# Altium schematic sheet RFID_CRD.SchDoc
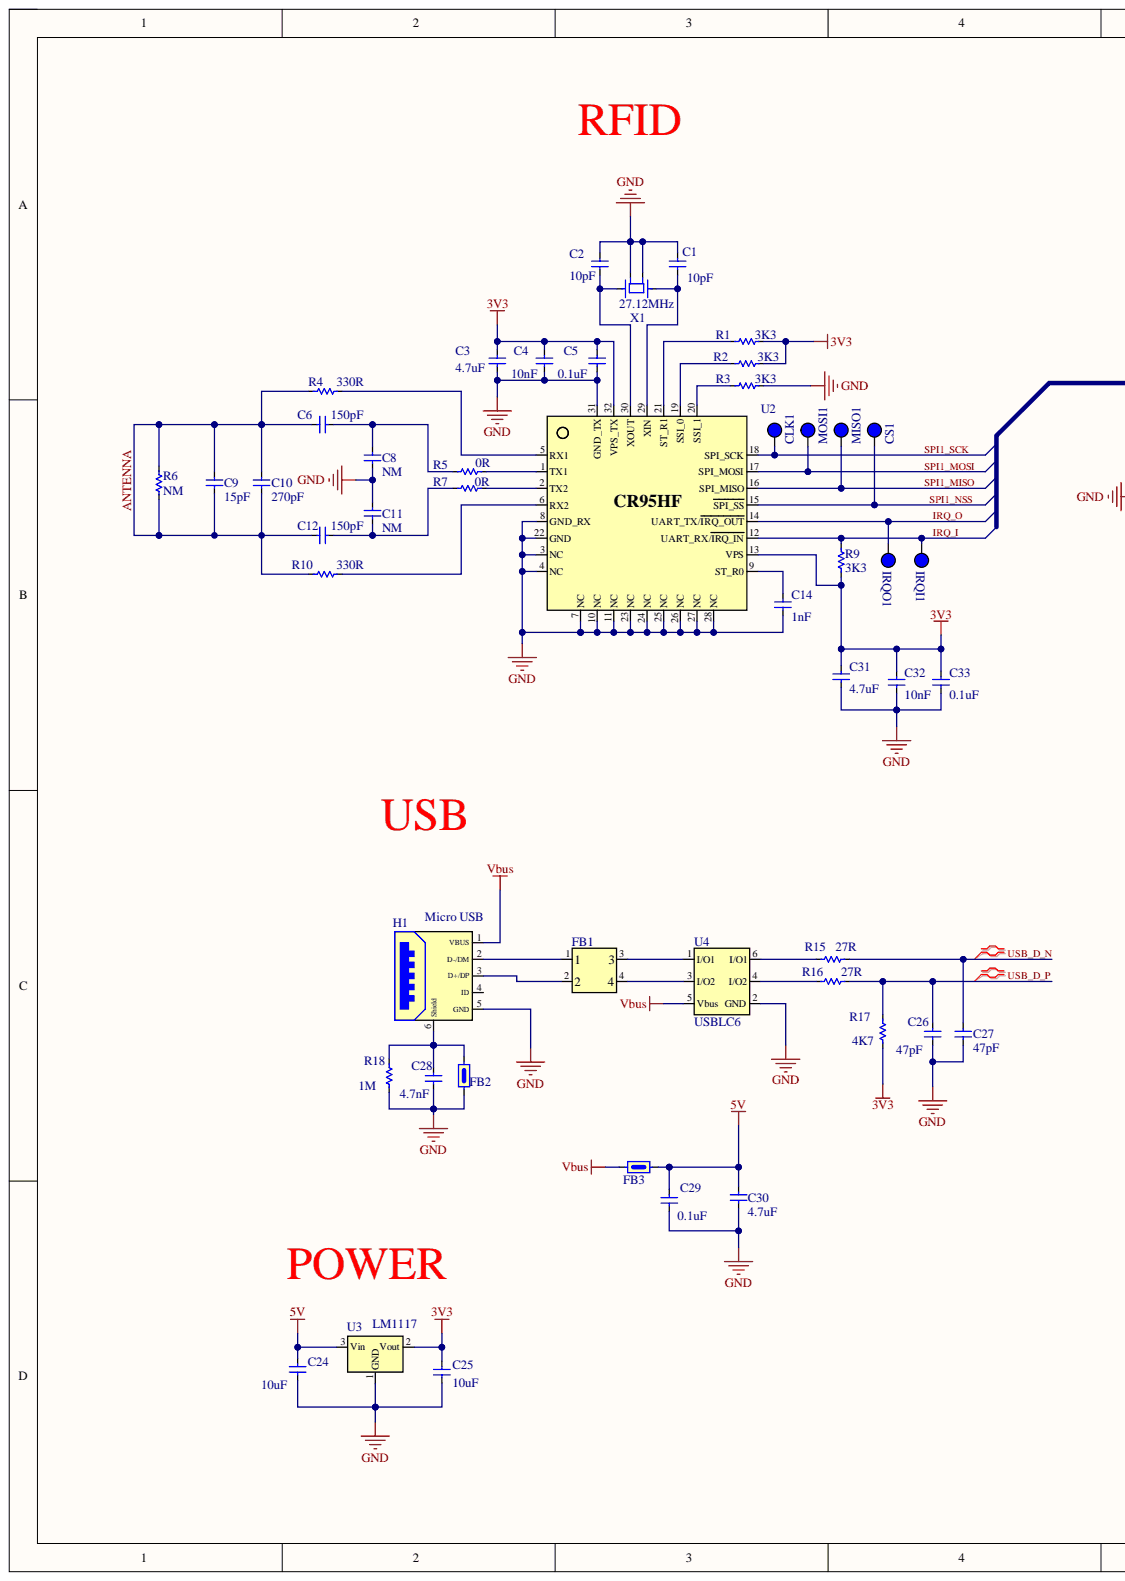
[diagram p0: RFID_CRD.SchDoc, left]
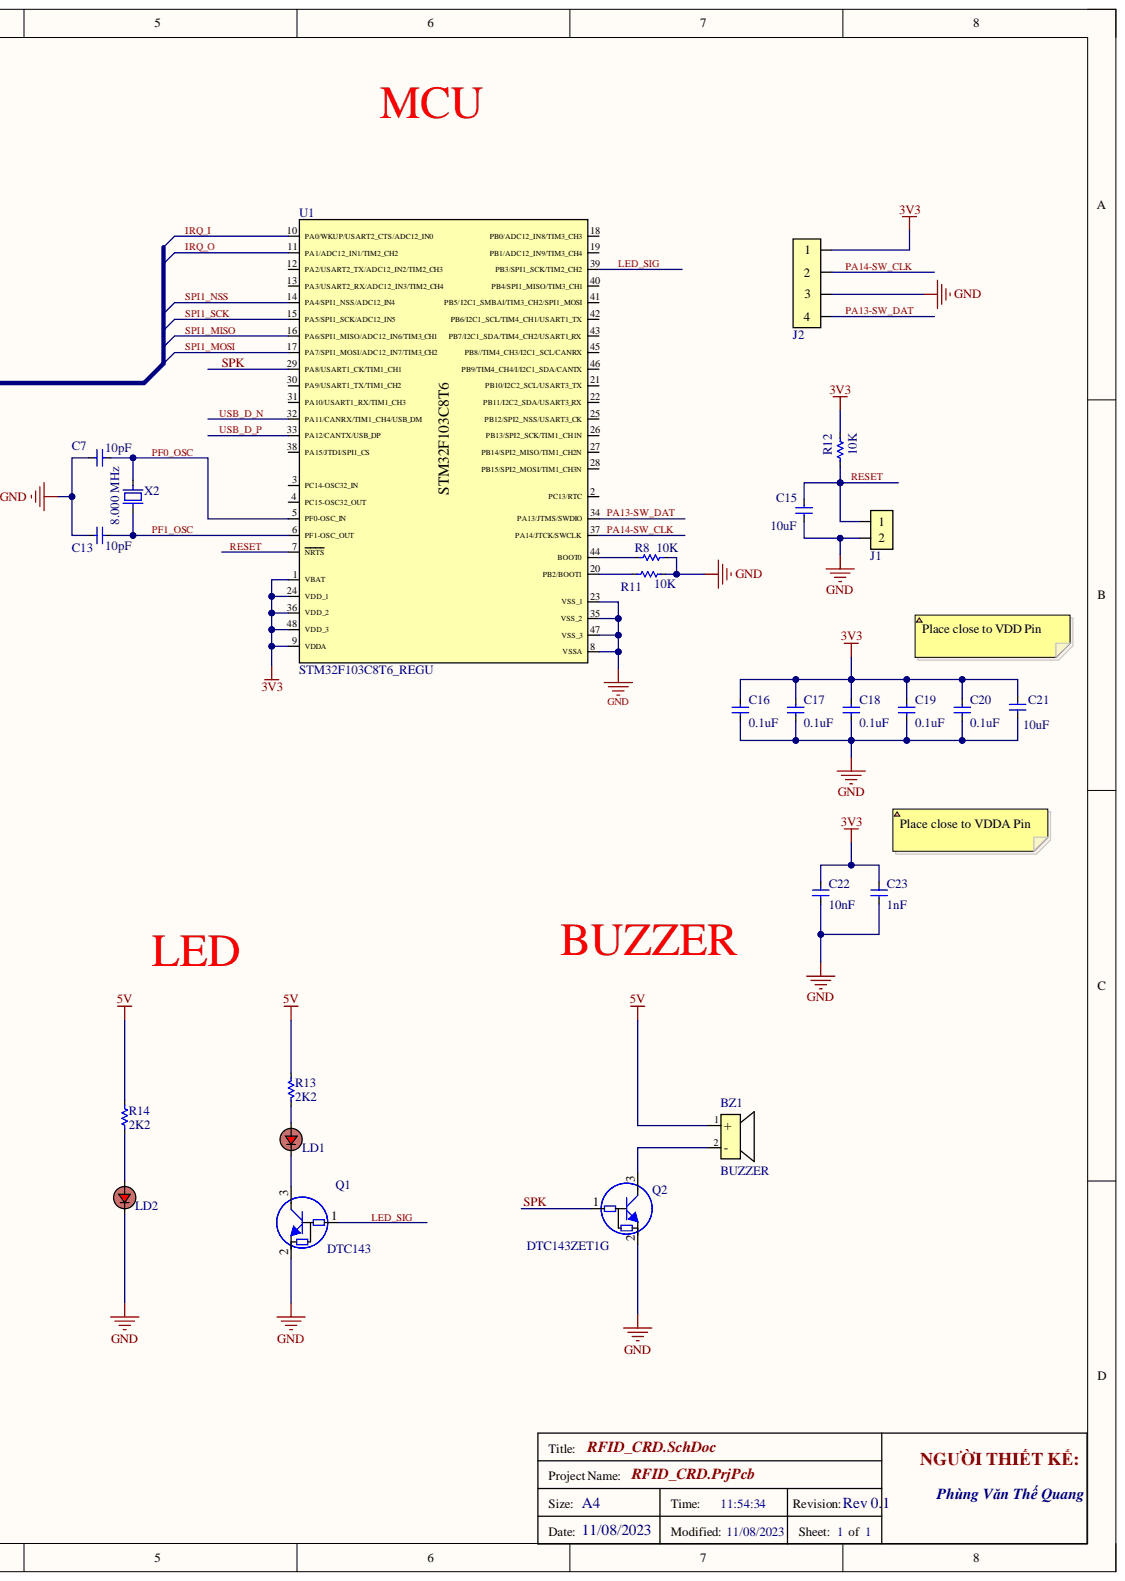
[diagram p1: RFID_CRD.SchDoc, right]

## Parts
BZ1  BUZZER  [BUZZER_9005]
C1  10pF  [CAPC-0603-1608N]
C2  10pF  [CAPC-0603-1608N]
C3  4.7uF  [CAPC-0603-1608N]
C4  10nF  [CAPC-0603-1608N]
C5  0.1uF  [CAPC-0603-1608N]
C6  150pF  [CAPC-0603-1608N]
C7  10pF  [CAPC-0603-1608N]
C8  NM  [CAPC-0603-1608N]  (no BOM)
C9  15pF  [CAPC-0603-1608N]
C10  270pF  [CAPC-0603-1608N]
C11  NM  [CAPC-0603-1608N]  (no BOM)
C12  150pF  [CAPC-0603-1608N]
C13  10pF  [CAPC-0603-1608N]
C14  1nF  [CAPC-0603-1608N]
C15  10uF  [CAPC-0603-1608N]
C16  0.1uF  [CAPC-0603-1608N]
C17  0.1uF  [CAPC-0603-1608N]
C18  0.1uF  [CAPC-0603-1608N]
C19  0.1uF  [CAPC-0603-1608N]
C20  0.1uF  [CAPC-0603-1608N]
C21  10uF  [CAPC-0603-1608N]
C22  10nF  [CAPC-0603-1608N]
C23  1nF  [CAPC-0603-1608N]
C24  10uF  [CAPC-0603-1608N]
C25  10uF  [CAPC-0603-1608N]
C26  47pF  [CAPC-0603-1608N]
C27  47pF  [CAPC-0603-1608N]
C28  4.7nF  [CAPC-0603-1608N]  (no BOM)
C29  0.1uF  [CAPC-0603-1608N]
C30  4.7uF  [CAPC-0603-1608N]
C31  4.7uF  [CAPC-0603-1608N]
C32  10nF  [CAPC-0603-1608N]
C33  0.1uF  [CAPC-0603-1608N]
CLK1  TP  [TEST POINT]  (value not drawn)  (no BOM)
CS1  TP  [TEST POINT]  (value not drawn)  (no BOM)
FB1  Common Mode Choke  [ICMF112]  (value not drawn)
FB2  BLM21PG121SN1D  [L.SMD.0805]  (value not drawn)
FB3  BLM21PG121SN1D  [L.SMD.0805]  (value not drawn)
H1  Micro USB  [USB-Mup-U514]
IRQI1  TP  [TEST POINT]  (value not drawn)  (no BOM)
IRQO1  TP  [TEST POINT]  (value not drawn)  (no BOM)
J1  HDR-1x2-S  [HDR1X2]  (value not drawn)
J2  HDR1x4  [HDR1X4]  (value not drawn)
LD1  =Value  [LED_0805]
LD2  =Value  [LED_5MM - rect]
MISO1  TP  [TEST POINT]  (value not drawn)  (no BOM)
MOSI1  TP  [TEST POINT]  (value not drawn)  (no BOM)
Q1  DTC143  [SOT-416]
Q2  DTC143ZET1G  [SOT-416]
R1  3K3  [R.SMD_0603]
R2  3K3  [R.SMD_0603]
R3  3K3  [R.SMD_0603]
R4  330R  [R.SMD_0603]
R5  0R  [R.SMD_0603]
R6  NM  [R.SMD_0603]  (no BOM)
R7  0R  [R.SMD_0603]
R8  10K  [R.SMD_0603]
R9  3K3  [R.SMD_0603]
R10  330R  [R.SMD_0603]
R11  10K  [R.SMD_0603]
R12  10K  [R.SMD_0603]
R13  2K2  [R.SMD_0603]
R14  2K2  [R.SMD_0603]
R15  27R  [R.SMD_0603]  (no BOM)
R16  27R  [R.SMD_0603]  (no BOM)
R17  4K7  [R.SMD_0603]  (no BOM)
R18  1M  [R.SMD_0603]  (no BOM)
U1  STM32F103C8T6_REGU  Part name: STM32F103C8T6  [LQFP-48]
U2  =Value  [VFQFPN32]
U3  LM1117  [SOT-223]
U4  USBLC6  [SOT-23-6L]
X1  27.12MHz  [Crystal-3225]
X2  8.000 MHz  [CRYSTAL-Can (3x8)]

## Nets
3V3: C3.2 C4.2 C5.2 C16.2 C17.2 C18.2 C19.2 C20.2 C21.1 C22.2 C23.2 C25.1 C31.2 C32.2 C33.2 J2.1 R1.2 R2.2 R9.1 R12.1 R17.2 U1.1(VBAT) U1.9(VDDA) U1.24(VDD_1) U1.36(VDD_2) U1.48(VDD_3) U2.13(VPS) U2.32(VPS_TX) U3.2
5V: BZ1.1 C24.1 C29.1 C30.1 FB3.1 R13.2 R14.2 U3.3
GND: C1.1 C2.1 C3.1 C4.1 C5.1 C7.2 C8.2 C11.1 C13.2 C14.1 C15.2 C16.1 C17.1 C18.1 C19.1 C20.1 C21.2 C22.1 C23.1 C24.2 C25.2 C26.1 C27.1 C28.1 C29.2 C30.2 C31.1 C32.1 C33.1 FB2.1 H1.5(GND) J1.2 J2.3 LD2.2 Q1.2 Q2.2 R3.2 R8.1 R11.2 R18.2 U1.8(VSSA) U1.23(VSS_1) U1.35(VSS_2) U1.47(VSS_3) U2.3(NC) U2.4(NC) U2.7(NC) U2.8(GND_RX) U2.10(NC) U2.11(NC) U2.22(GND) U2.23(NC) U2.24(NC) U2.25(NC) U2.26(NC) U2.27(NC) U2.28(NC) U2.31(GND_TX) U3.1 U4.2(GND) X1.2 X1.4
Vbus: FB3.2 H1.1(VBUS) U4.5(Vbus)
ANTENNA: C6.1 C9.1 C9.2 C10.1 C10.2 C12.1 R4.1 R6.1 R6.2 R10.1
IRQ_I: IRQI1.1 R9.2 U1.10(PA0/WKUP/USART2_CTS/ADC12_IN0) U2.12(UART_RX/IRQ_IN)
IRQ_O: IRQO1.1 U1.11(PA1/ADC12_IN1/TIM2_CH2) U2.14(UART_TX/IRQ_OUT)
LED_SIG: Q1.1 U1.39(PB3/SPI1_SCK/TIM2_CH2)
PA13-SW_DAT: J2.4 U1.34(PA13/JTMS/SWDIO)
PA14-SW_CLK: J2.2 U1.37(PA14/JTCK/SWCLK)
PF0_OSC: C7.1 U1.5(PF0-OSC_IN) X2.2
PF1_OSC: C13.1 U1.6(PF1-OSC_OUT) X2.1
RESET: C15.1 J1.1 R12.2 U1.7(NRTS)
SPI1_MISO: MISO1.1 U1.16(PA6/SPI1_MISO/ADC12_IN6/TIM3_CH1) U2.16(SPI_MISO)
SPI1_MOSI: MOSI1.1 U1.17(PA7/SPI1_MOSI/ADC12_IN7/TIM3_CH2) U2.17(SPI_MOSI)
SPI1_NSS: CS1.1 U1.14(PA4/SPI1_NSS/ADC12_IN4) U2.15(SPI_SS)
SPI1_SCK: CLK1.1 U1.15(PA5/SPI1_SCK/ADC12_IN5) U2.18(SPI_SCK)
SPK: Q2.1 U1.29(PA8/USART1_CK/TIM1_CH1)
USB_D_N: C27.2 R15.1 U1.32(PA11/CANRX/TIM1_CH4/USB_DM)
USB_D_P: C26.2 R16.1 R17.1 U1.33(PA12/CANTX/USB_DP)
NetBZ1_2: BZ1.2 Q2.3
NetC1_2: C1.2 U2.29(XIN) X1.1
NetC2_2: C2.2 U2.30(XOUT) X1.3
NetC6_2: C6.2 C8.1 R5.2
NetC11_2: C11.2 C12.2 R7.2
NetC14_2: C14.2 U2.9(ST_R0)
NetC28_2: C28.2 FB2.2 H1.6(Shield) R18.1
NetFB1_1: FB1.1 H1.2(D-/DM)
NetFB1_2: FB1.2 H1.3(D+/DP)
NetFB1_3: FB1.3 U4.1(I/O1)
NetFB1_4: FB1.4 U4.3(I/O2)
NetLD1_1: LD1.1 R13.1
NetLD1_2: LD1.2 Q1.3
NetLD2_1: LD2.1 R14.1
NetR1_1: R1.1 U2.21(ST_R1)
NetR2_1: R2.1 U2.19(SSI_0)
NetR3_1: R3.1 U2.20(SSI_1)
NetR4_2: R4.2 U2.5(RX1)
NetR5_1: R5.1 U2.1(TX1)
NetR7_1: R7.1 U2.2(TX2)
NetR8_2: R8.2 U1.44(BOOT0)
NetR10_2: R10.2 U2.6(RX2)
NetR11_1: R11.1 U1.20(PB2/BOOT1)
NetR15_2: R15.2 U4.6(I/O1)
NetR16_2: R16.2 U4.4(I/O2)

Not connected: H1 4(ID); U1 2(PC13/RTC) 3(PC14-OSC32_IN) 4(PC15-OSC32_OUT) 12(PA2/USART2_TX/ADC12_IN2/TIM2_CH3) 13(PA3/USART2_RX/ADC12_IN3/TIM2_CH4) 18(PB0/ADC12_IN8/TIM3_CH3) 19(PB1/ADC12_IN9/TIM3_CH4) 21(PB10/I2C2_SCL/USART3_TX) 22(PB11/I2C2_SDA/USART3_RX) 25(PB12/SPI2_NSS/USART3_CK) 26(PB13/SPI2_SCK/TIM1_CH1N) 27(PB14/SPI2_MISO/TIM1_CH2N) 28(PB15/SPI2_MOSI/TIM1_CH3N) 30(PA9/USART1_TX/TIM1_CH2) 31(PA10/USART1_RX/TIM1_CH3) 38(PA15/JTDI/SPI1_CS) 40(PB4/SPI1_MISO/TIM3_CH1) 41(PB5/_I2C1_SMBAl/TIM3_CH2/SPI1_MOSI) 42(PB6/I2C1_SCL/TIM4_CH1/USART1_TX) 43(PB7/I2C1_SDA/TIM4_CH2/USART1_RX) 45(PB8//TIM4_CH3/I2C1_SCL/CANRX) 46(PB9/TIM4_CH4/I/I2C1_SDA/CANTX)

## Library data of the parts
BZ1 = BUZZER: 5V Magneticc Buzzer, 85dB, hight 6.5mm; Manufacturer: China; Part name: CX-0905C; Component Kind: Standard; Library Name: AT-COM_SCH.SchLib; Package: DIP2; Part number: CX-0905C
C1 C2 C7 C13 = CAP CER: CAP CER 10PF 50V C0G/NPO 0603; Manufacturer: Yageo; Part number: CC0603JRNPO9BN100; Type: SMD; Component Kind: Standard; Library Name: AT-COM_SCH.SchLib; Package: 0603
C3 = CAP CER: CAP CER 4.7UF 10V X5R 0603; Manufacturer: Yageo; Part number: CC0603KRX5R6BB475; Type: SMD; Component Kind: Standard; Library Name: AT-COM_SCH.SchLib; Package: 0603
C4 = CAP CER: CAP CER 10nF 50V X7R 0603; Manufacturer: Yageo; Part number: CC0603JRX7R9BB103; Type: SMD; Component Kind: Standard; Library Name: AT-COM_SCH.SchLib; Package: 0603
C5 C16-C20 C29 = CAP CER: CAP CER 0.1UF 50V X7R 0603; Manufacturer: Yageo; Part number: CC0603KRX7R9BB104; Type: SMD; Component Kind: Standard; Library Name: AT-COM_SCH.SchLib; Package: 0603
C6 C12 = CAP CER: CAP CER 150PF 50V C0G/NPO 0603; Manufacturer: Yageo; Part number: CC0603JRNPO9BN151; Type: SMD; Component Kind: Standard; Library Name: AT-COM_SCH.SchLib; Package: 0603
C8 C11 = CAP CER: CAP CER 270PF 50V NPO 0603; Manufacturer: Yageo; Part number: CC0603FRNPO9BN271; Type: SMD; Library Name: AT-COM_SCH.SchLib; Package: 0603
C9 = CAP CER: CAP CER 15PF 50V C0G/NPO 0603; Manufacturer: Yageo; Part number: CC0603JRNPO9BN150; Type: SMD; Component Kind: Standard; Library Name: AT-COM_SCH.SchLib; Package: 0603
C10 = CAP CER: CAP CER 270PF 50V NPO 0603; Manufacturer: Yageo; Part number: CC0603FRNPO9BN331; Type: SMD; Component Kind: Standard; Library Name: AT-COM_SCH.SchLib; Package: 0603
C14 = CAP CER: CAP CER 1000PF 50V X7R 0603; Manufacturer: Yageo; Part number: CC0603KRX7R9BB102; Type: SMD; Component Kind: Standard; Library Name: AT-COM_SCH.SchLib; Package: 0603
C15 C21 C24 C25 = CAP CER: CAP CER 10UF 10V X5R 0603; Manufacturer: Samsung Electro-Mechanics America, Inc.; Part number: CL10A106KP8NNNC; Type: SMD; Component Kind: Standard; Library Name: AT-COM_SCH.SchLib; Package: 0603
C22 C32 = CAP CER: CAP CER 10000PF 50V X7R 0603; Manufacturer: Yageo; Part number: CC0603KRX7R9BB103; Component Kind: Standard; Library Name: AT-COM_SCH.SchLib; Package: 0603; Type: Cap
C23 = CAP CER: CAP CER 1UF 50V X5R 0603 10%; Manufacturer: Yageo; Part number: CC0603KRX5R9BB105; Component Kind: Standard; Library Name: AT-COM_SCH.SchLib; Package: 0603; Type: Cap
C26 C27 = CAP CER: CAP CER 47PF 50V C0G/NPO 0603; Manufacturer: Yageo; Part number: CC0603JPNPO9BN470; Type: SMD; Component Kind: Standard; Library Name: AT-COM_SCH.SchLib; Package: 0603
C28 = CAP CER: CAP CER 4700PF 50V X7R 0603; Manufacturer: Yageo; Part number: CC0603KRX7R9BB472; Type: SMD; Library Name: AT-COM_SCH.SchLib; Package: 0603
C30 = CAP CER: CAP CER 4.7UF 25V X5R 0603; Manufacturer: Yageo; Part number: CC0603KRX5R8BB475; Type: SMD; Component Kind: Standard; Library Name: AT-COM_SCH.SchLib; Package: 0603
C31 = CAP CER: CAP CER 4.7UF 10V X5R 0603; Manufacturer: Yageo; Part number: CC0603KRX5R6BB475; Component Kind: Standard; Library Name: AT-COM_SCH.SchLib; Package: 0603; Type: Cap
C33 = CAP CER: CAP CER 0.1UF 50V X7R 0603; Manufacturer: Yageo; Part number: CC0603KRX7R9BB104; Component Kind: Standard; Library Name: AT-COM_SCH.SchLib; Package: 0603; Type: Cap
CLK1 CS1 IRQI1 IRQO1 MISO1 MOSI1 = Test Point: Description: Test point; Library Name: AT-COM_SCH.SchLib
FB1 = Common Mode Choke: CMC 500MA 2LN 90 OHM SMD; Manufacturer: Abracon LLC; Part number: ACM-0603-900-T; Component Kind: Standard; Library Name: TeO.SchLib
FB2 FB3 = Ferrite Bead: FERRITE BEAD 120 OHM 0805 1LN; Manufacturer: Murata Electronics; Component Kind: Standard; Library Name: TeO.SchLib; Package: 0805 (2012 Metric); Part number: BLM21PG121SN1D
H1 = USB-Micro: USB-B type Female mini SMD; Manufacturer: Taiwan; Part name: USBBF05-SMD; Component Kind: Standard; Library Name: TeO.SchLib; Part number: USBBF05-SMD
J1 = HDR-1x2-S: CONN HEADER VERT 2POS 3.96MM; Manufacturer: China; Component Kind: Standard; Library Name: TeO.SchLib; Type: TH
J2 = HDR-1x4-S: 2.54mm 1x4 Dual Male Pin Header; Manufacturer: China; Component Kind: Standard; ComponentLink1Description: Digikey Part link; ComponentLink2Description: Open DataSheet; Library Name: TeO.SchLib; Type: TH
LD1 LD2 = LED-RED: SMD-0805 High Bright Red Chip LED; Manufacturer: Harvatek Technologies; Part name: LED-0805-RED; Part number: HT17-2102SURC; Component Kind: Standard; Library Name: AT-COM_SCH.SchLib; Package: 0805
Q1 Q2 = DTC143ZET1G: TRANS PREBIAS NPN 200MW SOT-416; Manufacturer: ON Semiconductor; Type: SMD; Component Kind: Standard; Library Name: AT-COM_SCH.SchLib; Package / Case: SOT-416; Part Number: DTC143ZET1G
R1-R3 R9 = Resistor 1/4W: RES SMD 3K3 OHM 5% 1/10W 0603; Manufacturer: Yageo; Part number: RC0603JR-073K3L; Component Kind: Standard; Library Name: AT-COM_SCH.SchLib; Package: 0603
R4 R10 = Resistor 1/4W: RES SMD 330 OHM 5% 1/10W 0603; Manufacturer: Yageo; Part number: RC0603JR-07330RL; Component Kind: Standard; Library Name: AT-COM_SCH.SchLib; Package: 0603
R5-R7 = Resistor 1/4W: RES SMD 0 OHM 5% 1/10W 0603; Manufacturer: Yageo; Part name: R 0603 0R 5%; Part number: RC0603JR-070RL; Library Name: AT-COM_SCH.SchLib; Package: 0603
  R5 R7: Component Kind: Standard
R8 R11 R12 = Resistor 1/4W: RES SMD 10K OHM 5% 1/10W 0603; Manufacturer: Yageo; Part number: RC0603JR-0710KL; Component Kind: Standard; Library Name: AT-COM_SCH.SchLib; Package: 0603
R13 R14 = Resistor 1/4W: RES 2.2K OHM 5% 1/10W 0603; Manufacturer: Yageo; Part number: RC0603JR-072K2L; Component Kind: Standard; Library Name: AT-COM_SCH.SchLib; Package: 0603
R15 R16 = Resistor 1/4W: RES SMD 27 OHM 5% 1/10W 0603; Manufacturer: Yageo; Part number: RC0603JR-0727RL; Library Name: AT-COM_SCH.SchLib; Package: 0603; Type: RES
R17 = Resistor 1/4W: RES 4.7K OHM 5% 1/10W 0603; Manufacturer: Yageo; Part number: RC0603JR-074K7L; Library Name: AT-COM_SCH.SchLib; Package: 0603; Type: RES
R18 = Resistor 1/4W: RES SMD 1M OHM 5% 1/10W 0603; Manufacturer: Yageo; Part number: RC0603JR-071ML; Library Name: AT-COM_SCH.SchLib; Package: 0603
U1 = STM32F103C8T6_REGU: IC MCU 32BIT 64KB FLASH 48LQFP; Manufacturer: STMicroelectronics; Component Kind: Standard; Library Name: TeO.SchLib; Package: 48-LQFP; Part Number: STM32F103C8T6
U2 = (no symbol name): RF Transceiver 13.56MHz Multi Trans SPI UART 256byte VFQFPN-32; Manufacturer: STMicroelectronics; Part name: CR95HF-VMD5T; Component Kind: Standard; Library Name: AT-COM_SCH.SchLib; Package: QFN32; Part number: CR95HF-VMD5T; Type: SMD
U3 = AMS1117: 3.3V 1A Low dropout Voltage Regulator; Manufacturer: HTC; Part name: LM1117S-3.3; Component Kind: Standard; Library Name: AT-COM_SCH.SchLib; Package: SOT-223; Part number: LM1117S-3.3
U4 = USBLC6-2SC6: TVS DIODE 5.25V 17V SOT23-6; Manufacturer: STMicroelectronics; Component Kind: Standard; Library Name: TeO.SchLib; Package: SOT23-6; Part Number: USBLC6-2SC6
X1 = CRYSTAL 4 Pin: CRYSTAL 27.12MHZ 10PF SMD; Manufacturer: Abracon LLC; Part name: ABM3B-27.120MHZ-10-D2H-T; Component Kind: Standard; Library Name: AT-COM_SCH.SchLib; Package: 4-SMD; Part number: ABM3B-27.120MHZ-10-D2H-T; Type: SMD
X2 = CRYSTAL: CRYSTAL 8.0000MHZ 16PF TH; Manufacturer: Abracon LLC; Part number: AB308-8.000MHZ; Component Kind: Standard; Library Name: AT-COM_SCH.SchLib
